# Altium schematic sheet eFilament.SchDoc
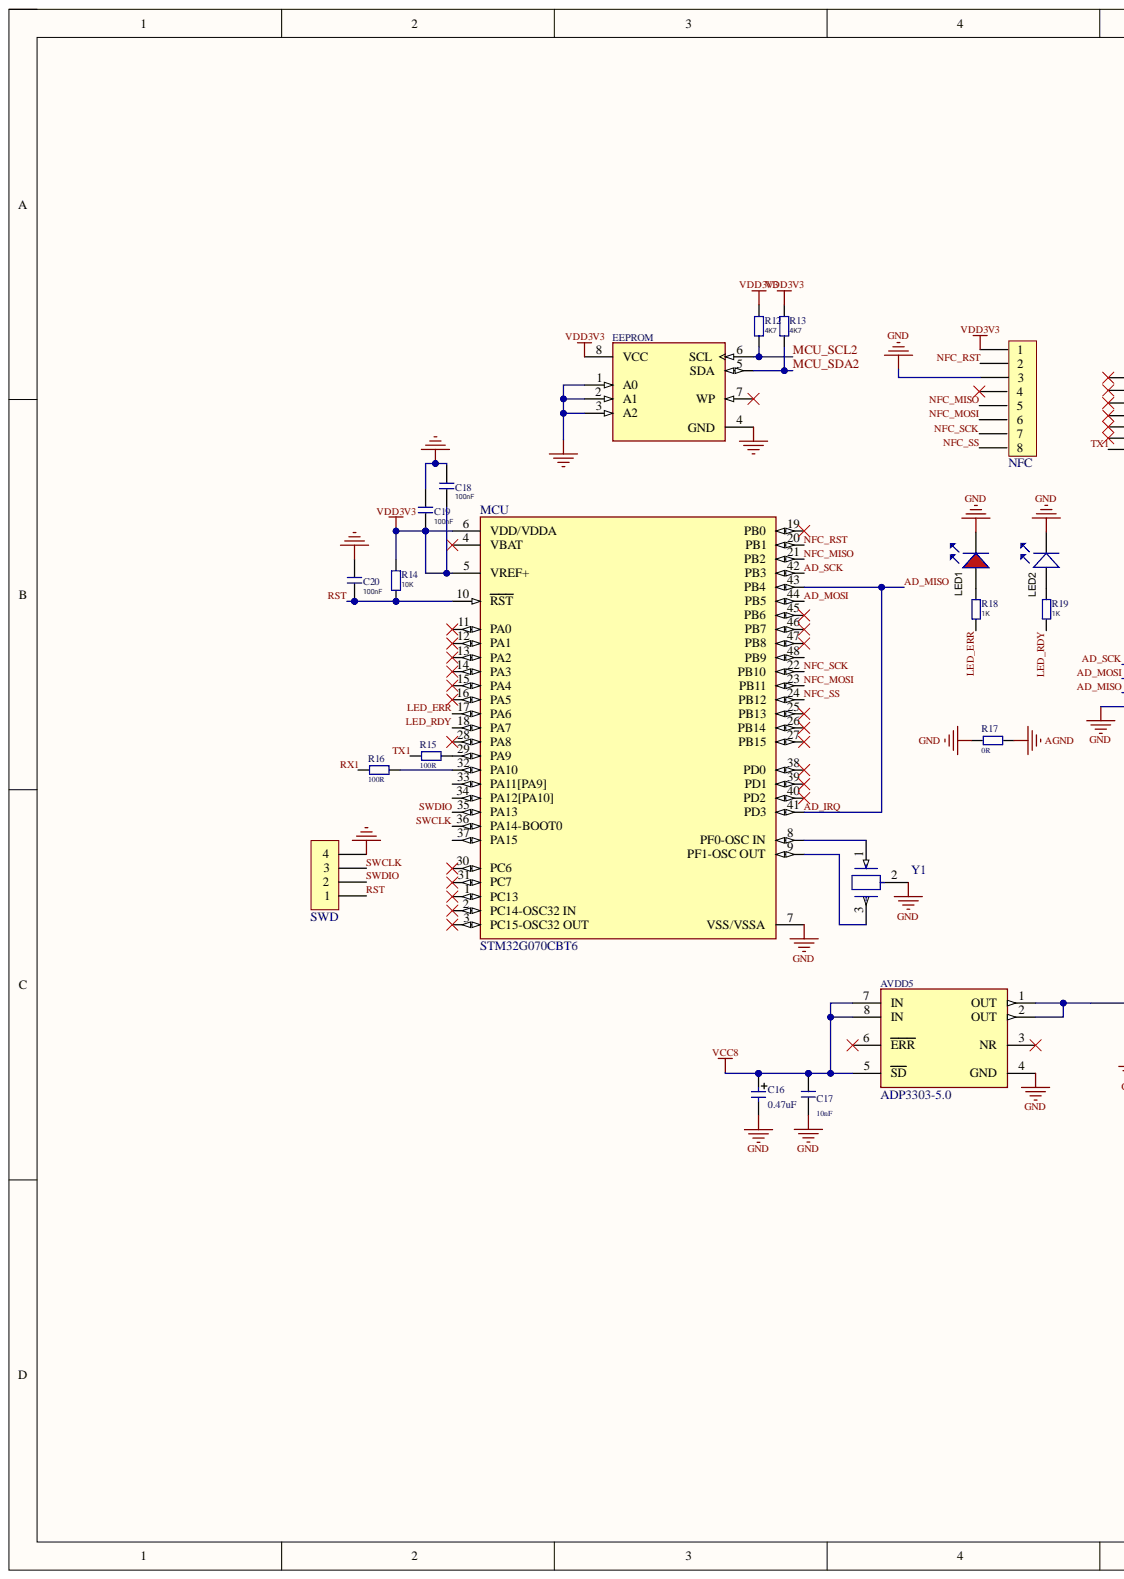
[diagram p0: eFilament.SchDoc, left]
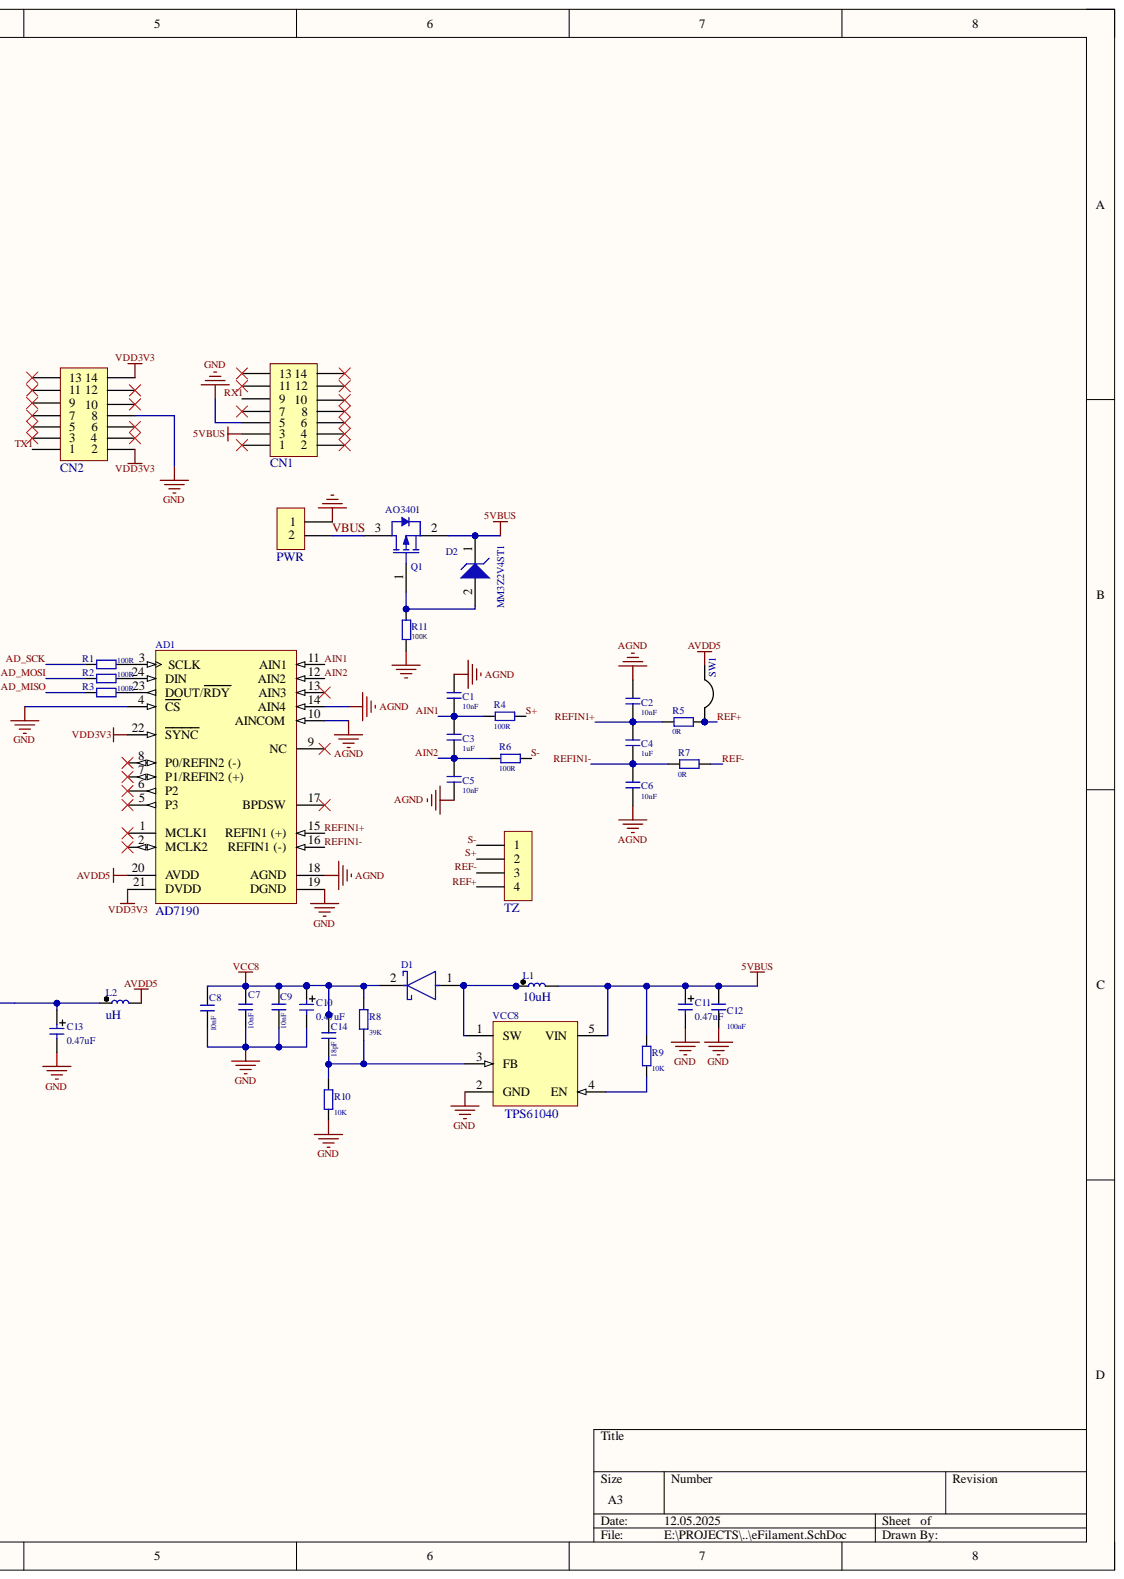
[diagram p1: eFilament.SchDoc, right]

## Parts
AD1  AD7190  [RU-24_N]
AVDD5  ADP3303-5.0  [FP-R-8-IPC_A]
C1  10nF  [C0603]
C2  10nF  [C0603]
C3  1uF  [C0603]
C4  1uF  [C0603]
C5  10nF  [C0603]
C6  10nF  [C0603]
C7  10nF  [C0603]
C8  10nF  [C0603]
C9  10nF  [C0603]
C10  0.47uF  [SMD A]
C11  0.47uF  [SMD A]
C12  100nF  [C0603]
C13  0.47uF  [SMD A]
C14  18pF  [C0603]
C16  0.47uF  [SMD A]
C17  10nF  [C0603]
C18  100nF  [C0603]
C19  100nF  [C0603]
C20  100nF  [C0603]
CN1  PLD 1.27 - 2x7  [HEADER 2x7 1.27MM TH VERT MALE]  (value not drawn)
CN2  PLD 1.27 - 2x7  [HEADER 2x7 1.27MM TH VERT MALE]  (value not drawn)
D1  =value  [FP-403AE-MFG]
D2  MM3Z2V4ST1  [ONSC-SOD-323-2-477-02_V]
EEPROM  =value  [FP-C04-057-SN-IPC_A]
L1  10uH  [L0603]
L2  uH  [L0603]
LED1  (no value)  [LED-0603-RED]
LED2  (no value)  [LED-0603-BLUE]
MCU  STM32G070CBT6  [FP-LQFP48-5B-MFG]
NFC  CONN 2.54 - 8  [PLS-1-8-R_NO]  (value not drawn)
PWR  JST GH 1.25 - 2pin  [JST-GH-2 (1.25)]  (value not drawn)
Q1  AO3401  [AO-SOT-23-3L_V]
R1  100R  [R0603]
R2  100R  [R0603]
R3  100R  [R0603]
R4  100R  [R0603]
R5  0R  [R0603]
R6  100R  [R0603]
R7  0R  [R0603]
R8  39K  [R0603]
R9  10K  [R0603]
R10  10K  [R0603]
R11  100K  [R0603]
R12  4K7  [R0603]
R13  4K7  [R0603]
R14  10K  [R0603]
R15  100R  [R0603]
R16  100R  [R0603]
R17  0R  [R0603]
R18  1K  [R0603]
R19  1K  [R0603]
SW1  =value  [SMD Bridge]
SWD  JST GH 1.25 - 4pin  [JST-GH-4 (1.25)]  (value not drawn)
TZ  JST GH 1.25 - 4pin  [JST-GH-4 (1.25)]  (value not drawn)
VCC8  TPS61040  [DBV0005A_N]
Y1  CSTNE8M00GH5C000R0  [FP-CSTNE8M00GH5C000R0-MFG]  (value not drawn)

## Nets
5VBUS: C11.1 C12.1 CN1.3 D2.1 L1.2 Q1.2 R9.2 VCC8.5(VIN)
AGND: AD1.10(AINCOM) AD1.14(AIN4) AD1.18(AGND) C1.2 C2.2 C5.1 C6.1 R17.1
AVDD5: AD1.20(AVDD) L2.2 SW1.1
GND: AD1.4(CS) AD1.19(DGND) AVDD5.4(GND) C7.2 C8.2 C9.2 C10.2 C11.2 C12.2 C13.2 C16.2 C17.2 C18.2 C19.2 C20.2 CN1.5 CN2.8 EEPROM.1(A0) EEPROM.2(A1) EEPROM.3(A2) EEPROM.4(GND) LED1.K LED2.K MCU.7(VSS/VSSA) NFC.3 PWR.1 R10.1 R11.2 R17.2 SWD.4 VCC8.2(GND) Y1.2
VCC8: AVDD5.5(SD) AVDD5.7(IN) AVDD5.8(IN) C7.1 C8.1 C9.1 C10.1 C14.1 C16.1 C17.1 D1.2 R8.2
VDD3V3: AD1.21(DVDD) AD1.22(SYNC) C18.1 C19.1 CN2.2 CN2.14 EEPROM.8(VCC) MCU.5(VREF+) MCU.6(VDD/VDDA) NFC.1 R12.2 R13.2 R14.2
AD_IRQ: MCU.41(PD3) MCU.43(PB4) R3.1
AD_MOSI: MCU.44(PB5) R2.1
AD_SCK: MCU.42(PB3) R1.1
AIN1: AD1.11(AIN1) C1.1 C3.1 R4.2
AIN2: AD1.12(AIN2) C3.2 C5.2 R6.2
LED_ERR: MCU.17(PA6) R18.2
LED_RDY: MCU.18(PA7) R19.2
MCU_SCL2: EEPROM.6(SCL) R12.1
MCU_SDA2: EEPROM.5(SDA) R13.1
NFC_MISO: MCU.21(PB2) NFC.5
NFC_MOSI: MCU.23(PB11) NFC.6
NFC_RST: MCU.20(PB1) NFC.2
NFC_SCK: MCU.22(PB10) NFC.7
NFC_SS: MCU.24(PB12) NFC.8
REF+: R5.1 SW1.2 TZ.4
REF-: R7.1 TZ.3
REFIN1+: AD1.15(REFIN1_(+)) C2.1 C4.1 R5.2
REFIN1-: AD1.16(REFIN1_(-)) C4.2 C6.2 R7.2
RST: C20.1 MCU.10(RST) R14.1 SWD.1
RX1: CN1.9 R16.2
S+: R4.1 TZ.2
S-: R6.1 TZ.1
SWCLK: MCU.36(PA14-BOOT0) SWD.3
SWDIO: MCU.35(PA13) SWD.2
TX1: CN2.1 R15.2
VBUS: PWR.2 Q1.3
NetAD1_3: AD1.3(SCLK) R1.2
NetAD1_23: AD1.23(DOUT/RDY) R3.2
NetAD1_24: AD1.24(DIN) R2.2
NetAVDD5_1: AVDD5.1(OUT) AVDD5.2(OUT) C13.1 L2.1
NetC14_2: C14.2 R8.1 R10.2 VCC8.3(FB)
NetD1_1: D1.1 L1.1 VCC8.1(SW)
NetD2_2: D2.2 Q1.1 R11.1
NetLED1_A: LED1.A R18.1
NetLED2_A: LED2.A R19.1
NetMCU_8: MCU.8(PF0-OSC_IN) Y1.1
NetMCU_9: MCU.9(PF1-OSC_OUT) Y1.3
NetMCU_29: MCU.29(PA9) R15.1
NetMCU_32: MCU.32(PA10) R16.1
NetR9_1: R9.1 VCC8.4(EN)

Not connected: AD1 1(MCLK1) 2(MCLK2) 5(P3) 6(P2) 7(P1/REFIN2_(+)) 8(P0/REFIN2_(-)) 9(NC) 13(AIN3) 17(BPDSW); AVDD5 3(NR) 6(ERR); CN1 1 2 4 6 7 8 10 11 12 13 14; CN2 3 4 5 6 7 9 10 11 12 13; EEPROM 7(WP); MCU 1(PC13) 2(PC14-OSC32_IN) 3(PC15-OSC32_OUT) 4(VBAT) 11(PA0) 12(PA1) 13(PA2) 14(PA3) 15(PA4) 16(PA5) 19(PB0) 25(PB13) 26(PB14) 27(PB15) 28(PA8) 30(PC6) 31(PC7) 33(PA11[PA9]) 34(PA12[PA10]) 37(PA15) 38(PD0) 39(PD1) 40(PD2) 45(PB6) 46(PB7) 47(PB8) 48(PB9); NFC 4

## Library data of the parts
AD1 = CMP-0313-01906-2: Quad 24-Bit Ultralow Noise Sigma-Delta Analog-to-Digital Converter with Serial, SPI Interface and PGA, 4.8KSPS, -40 to +105 degC, RU-24, Pb-Free, Reel; Manufacturer: Analog Devices; PartNumber: AD7190BRUZ-REEL; Channels: 4; Interface: Ser, SPI; Resolution (Bits): 24; Throughput Rate (SPS): 4.8K; Code_JEDEC: MO-153-AD; Component Kind: Standard; ComponentLink1Description: Manufacturer URL; ComponentLink1URL: http://www.analog.com/en/index.html; ComponentLink2Description: Datasheet; ComponentLink2URL: http://www.analog.com/static/imported-files/data_sheets/AD7190.pdf; ComponentLink3Description: Package Specification; ComponentLink3URL: http://www.analog.com/static/imported-files/packages/380523655245964505593671154107510036154RU24.pdf; ComponentLink4Description: Product URL; ComponentLink4URL: http://www.analog.com/en/analog-to-digital-converters/ad-converters/ad7190/products/product.html; DatasheetVersion: Rev.B; Generic Part Number: AD7190; Library Name: Altium Content Vault; Mounting Technology: Surface Mount; PackageDescription: 24-Lead Thin Shrink Small Outline Package [TSSOP]; PackageReference: RU-24; PackageVersion: 2002; Packing: Reel; RoHS: Y; value: AD7190
AVDD5 = CMP-2000-07573-2: IC REG LINEAR 5V 200MA 8SOIC; Accuracy: 0.8%; Dropout Voltage: 180mV; Max Input Voltage: 12V; Max Supply Current: 4mA; Min Input Voltage: 3.2V; Min Output Voltage: 2.7MV; Nominal Supply Current: 400uA; Number of Outputs: 1; Output Type: Fixed; Polarity: Positive; Power Supply Rejection Ratio (PSRR): 60dB; Case/Package: SOIC; Component Kind: Standard; Contact Plating: Tin; Height: 1.5mm; Lead Free: Contains Lead; Length: 5mm; Library Name: Altium Content Vault; Max Operating Temperature: 85°C; Max Output Current: 200mA; Max Output Voltage: 5V; Min Operating Temperature: -20°C; Mount: Surface Mount; Nominal Output Voltage: 5V; Number of Pins: 8; Number of Regulators: 1; Operating Supply Current: 400uA; Output Current: 200mA; Output Voltage: 5V; Output Voltage Accuracy: 0.8%; Package Quantity: 98; Packaging: Rail/Tube; Radiation Hardening: No; REACH SVHC: No SVHC; RoHS Compliant: Yes; Series: anyCAP®; Value: ADP3303-5.0; Width: 4mm
C1-C9 C12 C14 C17-C20 = C: Capacitor; Component Kind: Standard; Library Name: emt_passive.SchLib
  C1 C2 C5-C9 C17: value: 10nF
  C3 C4: value: 1uF
  C12 C18-C20: value: 100nF
  C14: value: 18pF
C10 C11 C13 C16 = CP: Capacitor polarized; Component Kind: Standard; value: 0.47uF; Library Name: emt_passive.SchLib
D1 = CMP-2000-04943-2: DIODE SCHOTTKY 40V 1A SMA; Manufacturer: ON Semiconductor; Manufacturer Part Number: SS14; Max Junction Temp: +125°C; Max Surge Forward Current: 40A; Peak Reverse Current: 0.2mA; Standoff Height: 0.05mm; Automotive: No; Category: Diode; Component Kind: Standard; ComponentLink2Description: Datasheet; ComponentLink2URL: https://www.onsemi.com/pub/Collateral/SS19-D.PDF; Device Class L1: Discrete Semiconductors; Device Class L2: Diodes; Device Class L3: Schottky Diodes; Digikey Description: DIODE SCHOTTKY 40V 1A SMA; Forward Current: 1A; Height: 2.5mm; Lead Free: Yes; Library Name: Altium Content Vault; Max Repetitive Reverse Voltage: 40V; Mouser Description: Schottky Diodes & Rectifiers SMA 40V 1.0A; Octopart: https://octopart.com/ss14-on+semiconductor-29205033; Package: 403AE; RoHS: TRUE; Temperature: -65°C to +125°C; Temperature Range High: +125°C; Temperature Range Low: -65°C
D2 = CMP-1060-00686-1: Zener Voltage Regulator, 300 mW, Tight Tolerance Portfolio, 2-Pin SOD-323, Pb-Free, Tape and Reel; Manufacturer: On Semiconductor; PartNumber: MM3Z9V1ST1G; P Max (W): 0.3; Component Kind: Standard; ComponentLink1Description: Manufacturer URL; ComponentLink1URL: http://www.onsemi.com/; ComponentLink2Description: Datasheet; ComponentLink2URL: http://www.onsemi.cn/pub_link/Collateral/MM3Z2V4ST1-D.PDF; ComponentLink3Description: Package Specification; ComponentLink3URL: http://www.onsemi.com/pub_link/Collateral/477-02.PDF.1; DatasheetVersion: Rev. 21; Library Name: Altium Content Vault; Mounting Technology: Surface Mount; PackageDescription: 2-Pin SOD, Body 1.7 x 1.25 mm; PackageReference: SOD-323-2-477-02; PackageVersion: Rev. H, 03/2007; Packing: Tape and Reel; RoHS: Pb-Free; value: MM3Z2V4ST1
EEPROM = CMP-06313-000087-1: IC EEPROM 64K I2C 400KHZ 8SOIC; Component Kind: Standard; Library Name: Altium Content Vault
L1 L2 = L: Inductor; Component Kind: Standard; Library Name: emt_passive.SchLib
  L1: value: 10uH
  L2: value: uH
LED1 = LED_SMD_RED: LED, 0805 SMD; Manufacturer 1: LITE-ON; Manufacturer Part Number 1: LTST-C171GKT; Material: GaP; Ratings: 6 mcd; 569 nm; SCDType: source; Component Kind: Standard; Package / Case: 0805; Supplier 1: Digi-Key; Supplier Part Number 1: 160-1423-1-ND; Library Name: emt_passive.SchLib
LED2 = LED_SMD_0603: LED, 0603 SMD; Manufacturer 1: LITE-ON; Manufacturer Part Number 1: LTST-C171GKT; Material: GaP; Ratings: 6 mcd; 569 nm; SCDType: source; Component Kind: Standard; Package / Case: 0805; Supplier 1: Digi-Key; Supplier Part Number 1: 160-1423-1-ND; Library Name: emt_passive.SchLib
MCU = CMP-12105-000112-1: IC MCU 32BIT 128KB FLASH 48LQFP; Component Kind: Standard; Library Name: Altium Content Vault; RoHS Compliant: Yes
Q1 = CMP-2000-05778-1: P-Channel MOSFET, 30 V, 4 A, -55 to 150 degC, 3-Pin SOT-23-3L, RoHS, Tape and Reel; Manufacturer: Alpha and Omega; Channel Mode: Enhancement; Continuous Drain Current: 4A; Drain Efficiency: NotRequired%; Drain-Source On-Res: 0.044ohm; Drain-Source On-Volt: 30V; Frequency (Max): NotRequiredMHz; Gate-Source Voltage (Max): ?12V; Noise Figure: NotRequireddB; Number of  Elements: 1; Output Power (Max): NotRequiredW; Polarity: P; Power Dissipation: 1.4W; Rad Hardened: No; Type: PowerMOSFET; Component Kind: Standard; Datasheet URL: http://www.aosmd.com/res/data_sheets/AO3401A.pdf; Datasheet Version: Rev. 3, 03/2011; Drain Current (Max): 4A; Library Name: Altium Content Vault; Manufacturer URL: http://www.aosmd.com/; Mounting: SurfaceMount; Mounting Technology: SurfaceMount; Operating Temp Range: -55Cto150C; Operating Temperature Classification: Military; Package Description: 3-Pin Small Outline Transistor, Body 2.95 x 1.6 mm, Pitch 0.95 mm; Package Reference: SOT-23-3L; Package Type: SOT-23; Package URL: http://www.aosmd.com/res/packaging_information/SOT-23-3L.pdf; Pin Count: 3; Power Gain: NotRequireddB; value: AO3401
R1-R19 = R: Resistor; Component Kind: Standard; Library Name: emt_passive.SchLib
  R1-R4 R6 R15 R16: value: 100R
  R5 R7 R17: value: 0R
  R8: value: 39K
  R9 R10 R14: value: 10K
  R11: value: 100K
  R12 R13: value: 4K7
  R18 R19: value: 1K
SW1 = SMD Bridge: Solderable SMD bridge; Component Kind: Standard; Library Name: rgr_lib.SchLib
VCC8 = CMP-0388-00113-2: 28 V, 400 mA Switch Boost Converter for White LED Applications, 1.8 to 6 V, -40 to 85 degC, 5-pin SOT23 (DBV5), Green (RoHS & no Sb/Br); Manufacturer: Texas Instruments; PartNumber: TPS61040DBVR; Dimming: TRUE; Iout(Max)(A): 0.09; Iq(Typ)(mA): 0.028; Rating: Catalog; SubFamily: White LED Driver; Switching Frequency(Max)(kHz): 1000; Vin(Max)(V): 6; Vin(Min)(V): 1.8; Code_JEDEC: MO-178; Component Kind: Standard; ComponentLink1Description: Manufacturer URL; ComponentLink1URL: http://www.ti.com/; ComponentLink2Description: Datasheet; ComponentLink2URL: http://www.ti.com/general/docs/lit/getliterature.tsp?genericPartNumber=TPS61040&fileType=pdf; ComponentLink3Description: Package Specification; ComponentLink3URL: http://www.ti.com/litv/pdf/mpds018i; DatasheetVersion: SLVS413F; Eco-Plan: Green (RoHS & no Sb/Br); Library Name: Altium Content Vault; Mounting Technology: Surface Mount; PackageDescription: 5-Pin Plastic Small Outline, Body 1.60 Ãƒâ€” 2.90 mm, Pitch 0.95 mm; PackageReference: DBV0005A; PackageVersion: 4073253-4/K; Topology: Boost; value: TPS61040
Y1 = CMP-06057-000001-1: CERAMIC RES 8.0000MHZ 33PF SMD; Frequency: 8MHz; Frequency Stability: 0.13%; Frequency Tolerance: 0.07%; Impedance: 40R; Capacitance: 33pF; Case/Package: SMD/SMT; Component Kind: Standard; Library Name: Altium Content Vault; Max Operating Temperature: 125°C; Min Operating Temperature: -40°C; Mount: Surface Mount; Packaging: Tape and Reel; Resistor Type: Ceramic
CN1 CN2 = PLD 1.27 - 2x7: Component Kind: Standard; Library Name: emt_connectors.SchLib
NFC = CONN 2.54 - 8: Component Kind: Standard; Library Name: emt_connectors.SchLib
PWR = JST GH 1.25 - 2pin: Component Kind: Standard; Library Name: emt_connectors.SchLib
SWD TZ = JST GH 1.25 - 4pin: Component Kind: Standard; Library Name: emt_connectors.SchLib
